# Altium schematic sheet P02_POWER_SUPPLIES_1_OF.SchDoc(P02_POWER_SUPPLIES_1_OF)
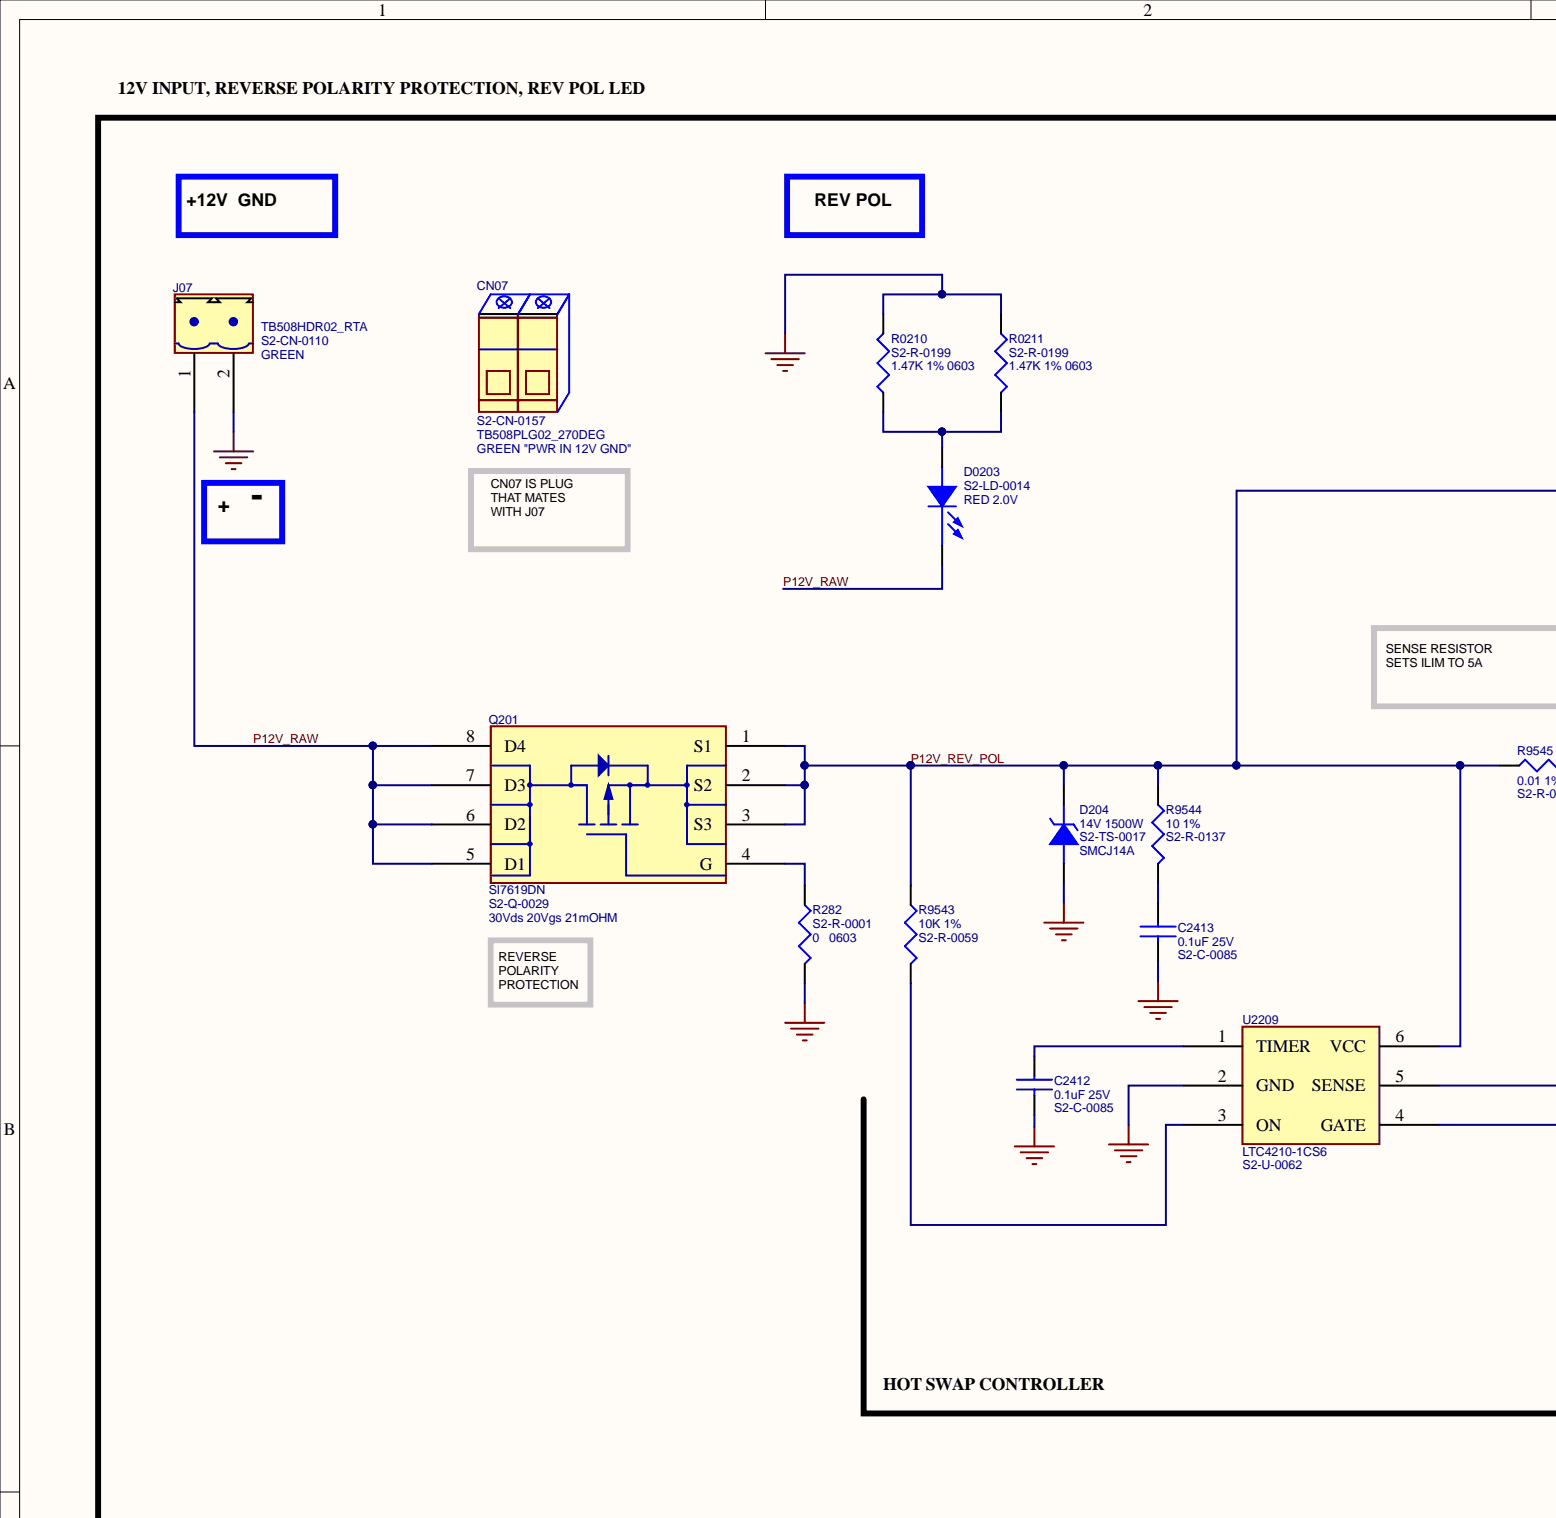
[diagram p0: P02_POWER_SUPPLIES_1_OF.SchDoc, top left]
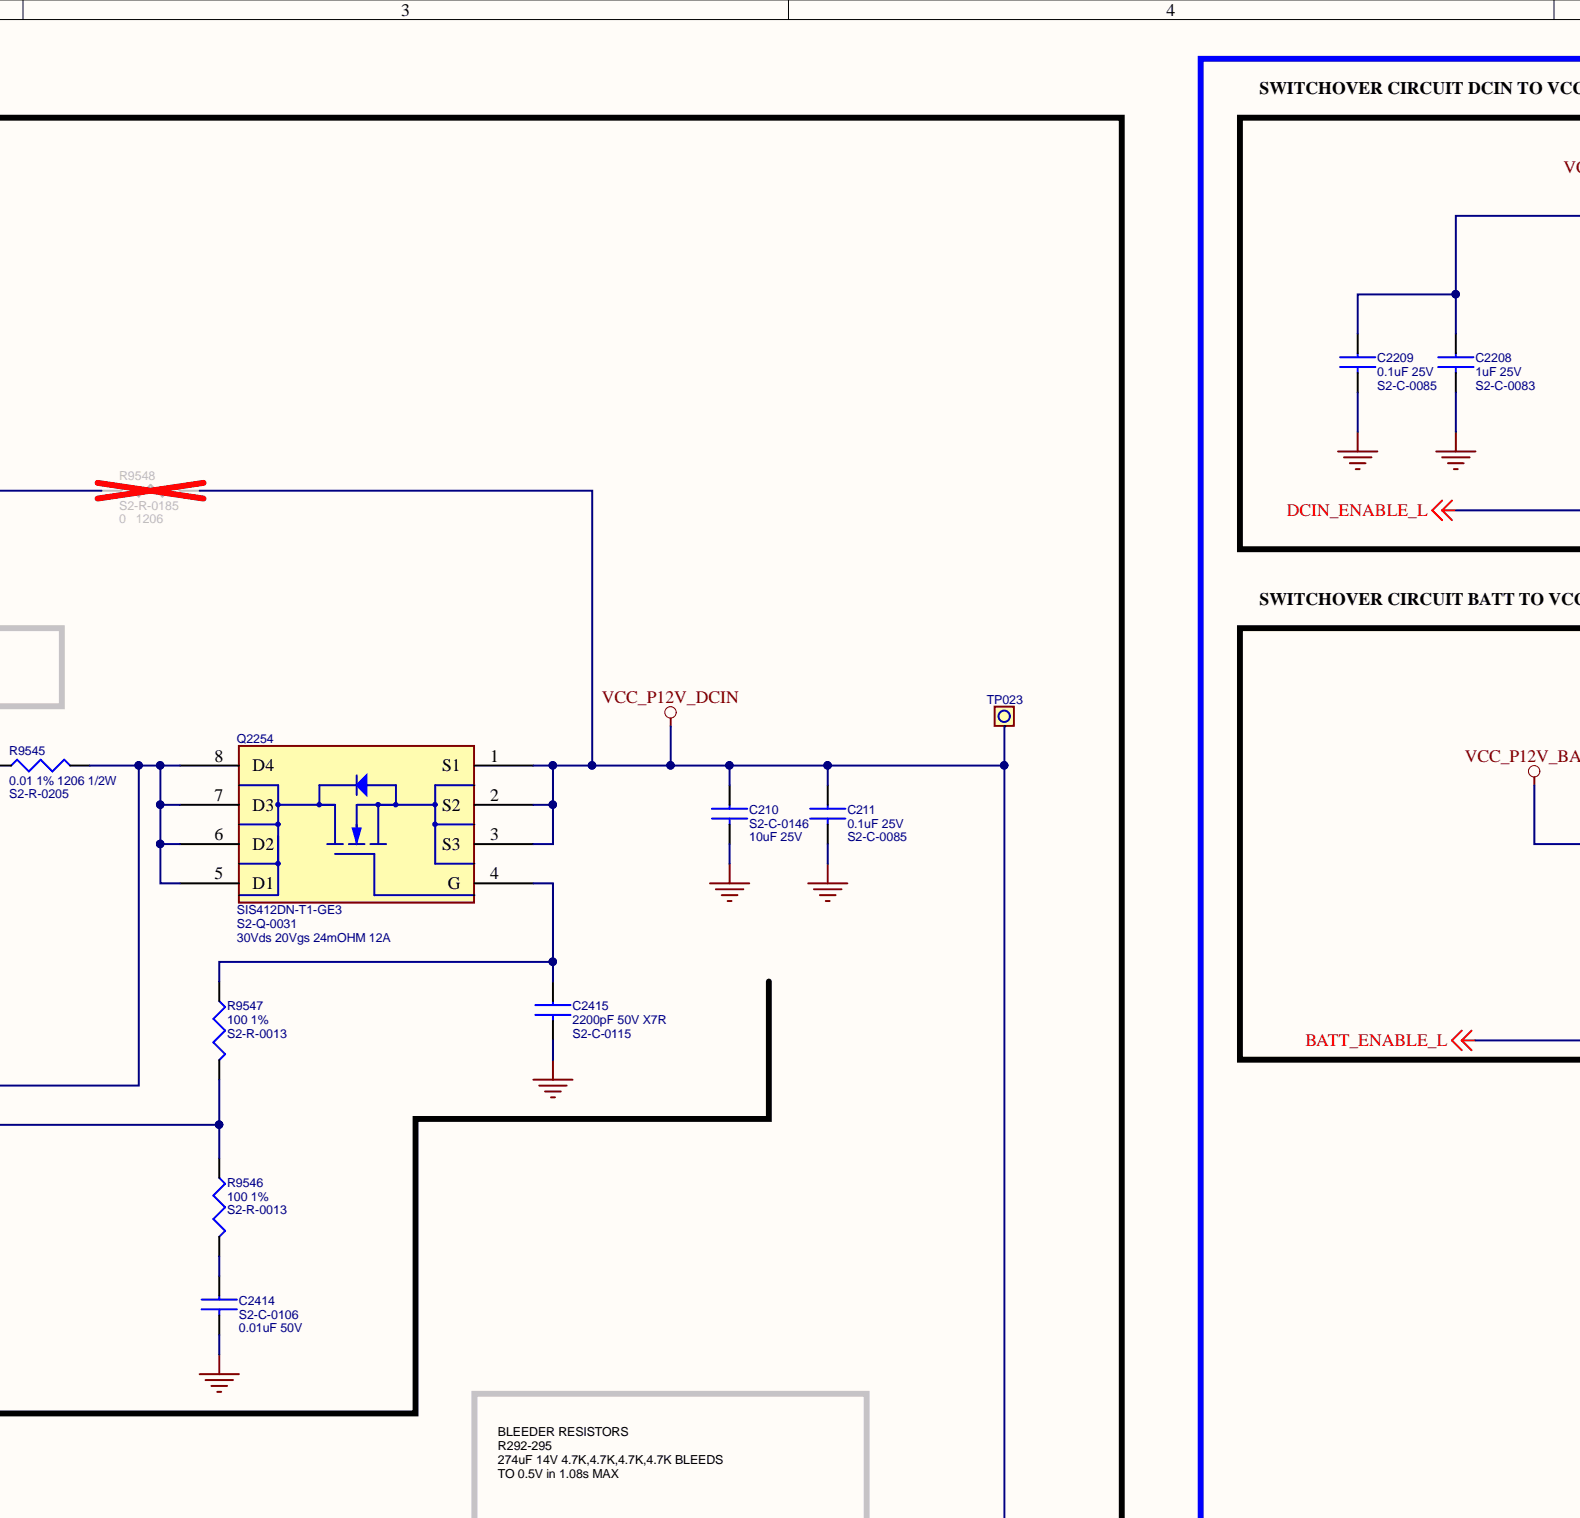
[diagram p1: P02_POWER_SUPPLIES_1_OF.SchDoc, top centre]
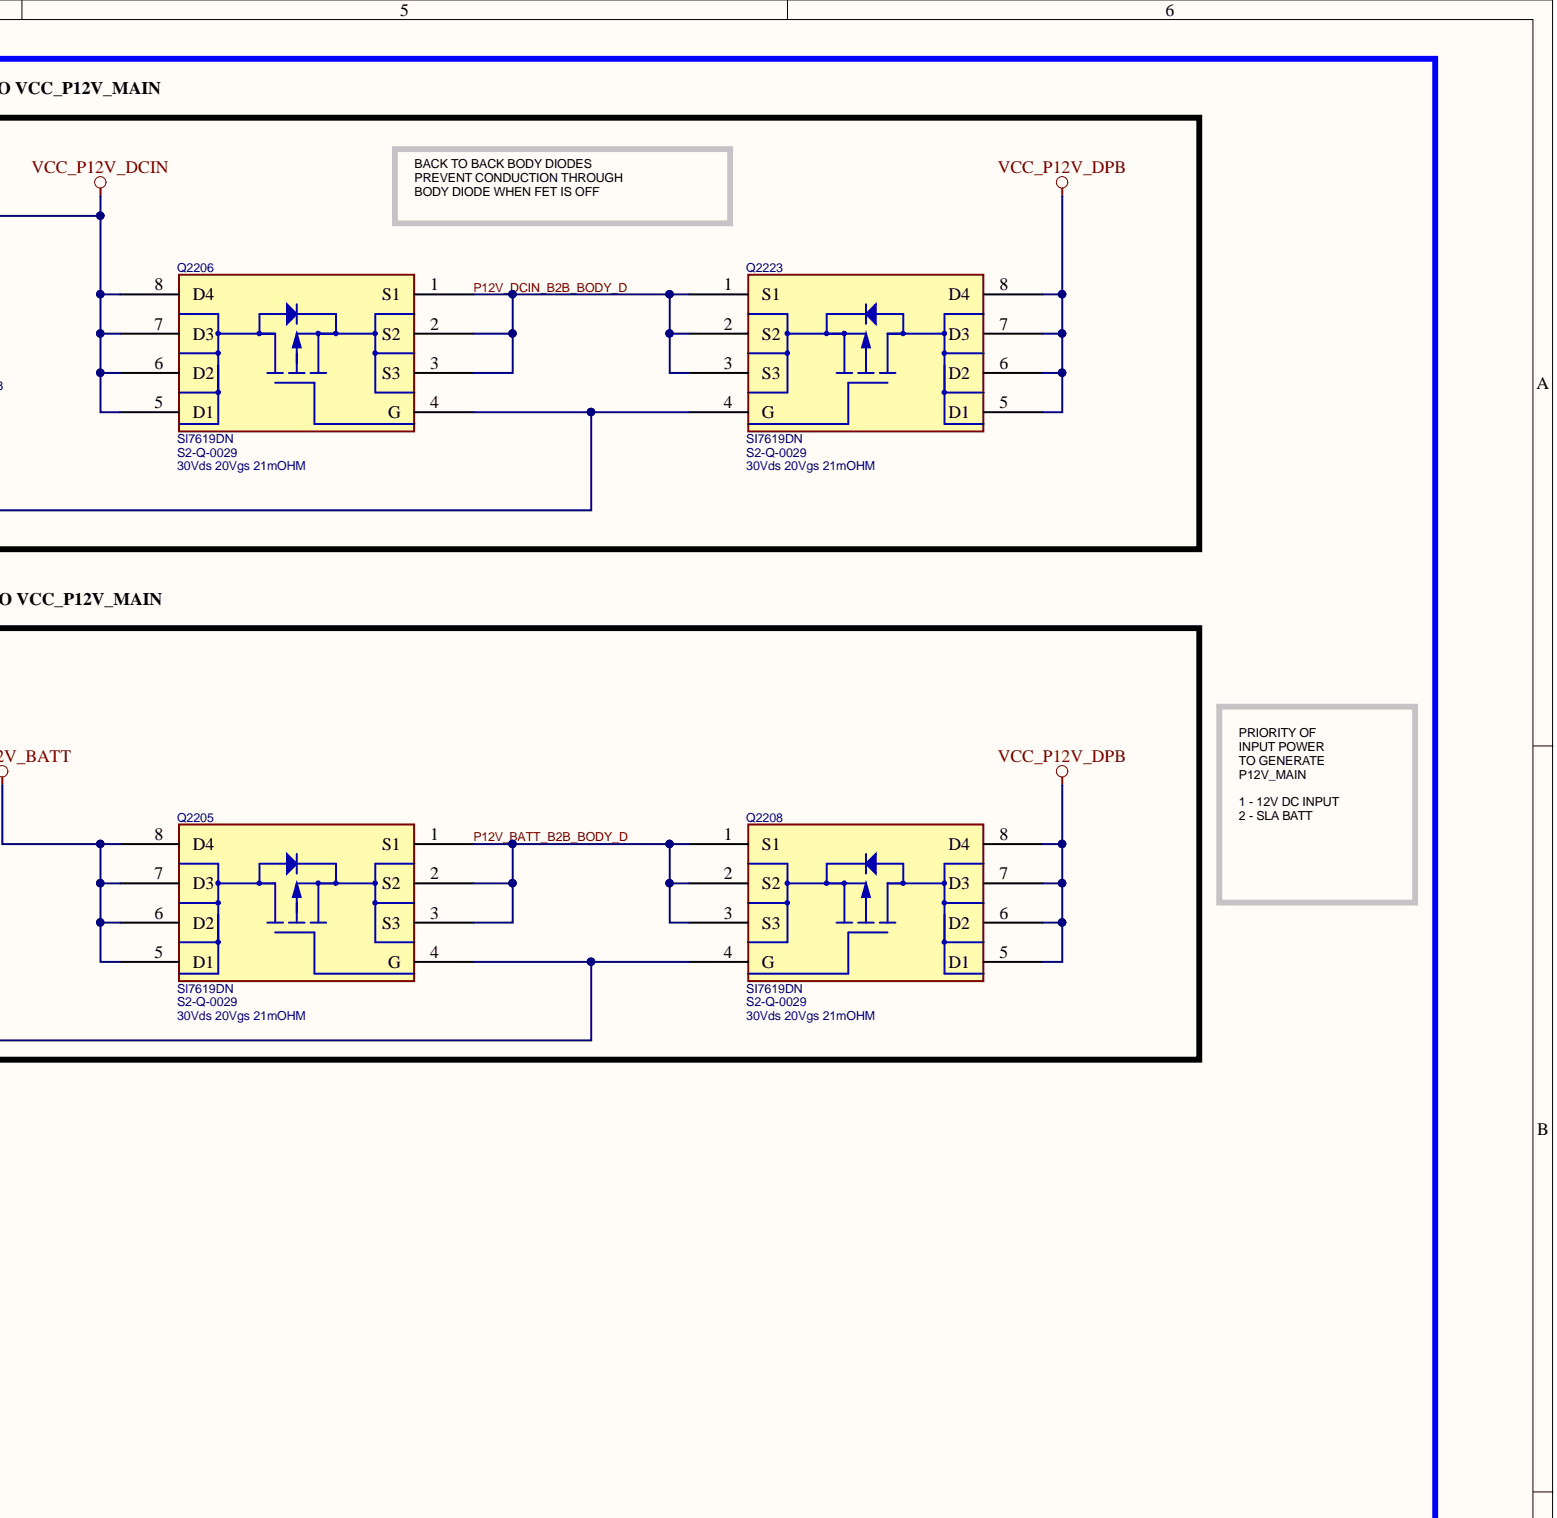
[diagram p2: P02_POWER_SUPPLIES_1_OF.SchDoc, top right]
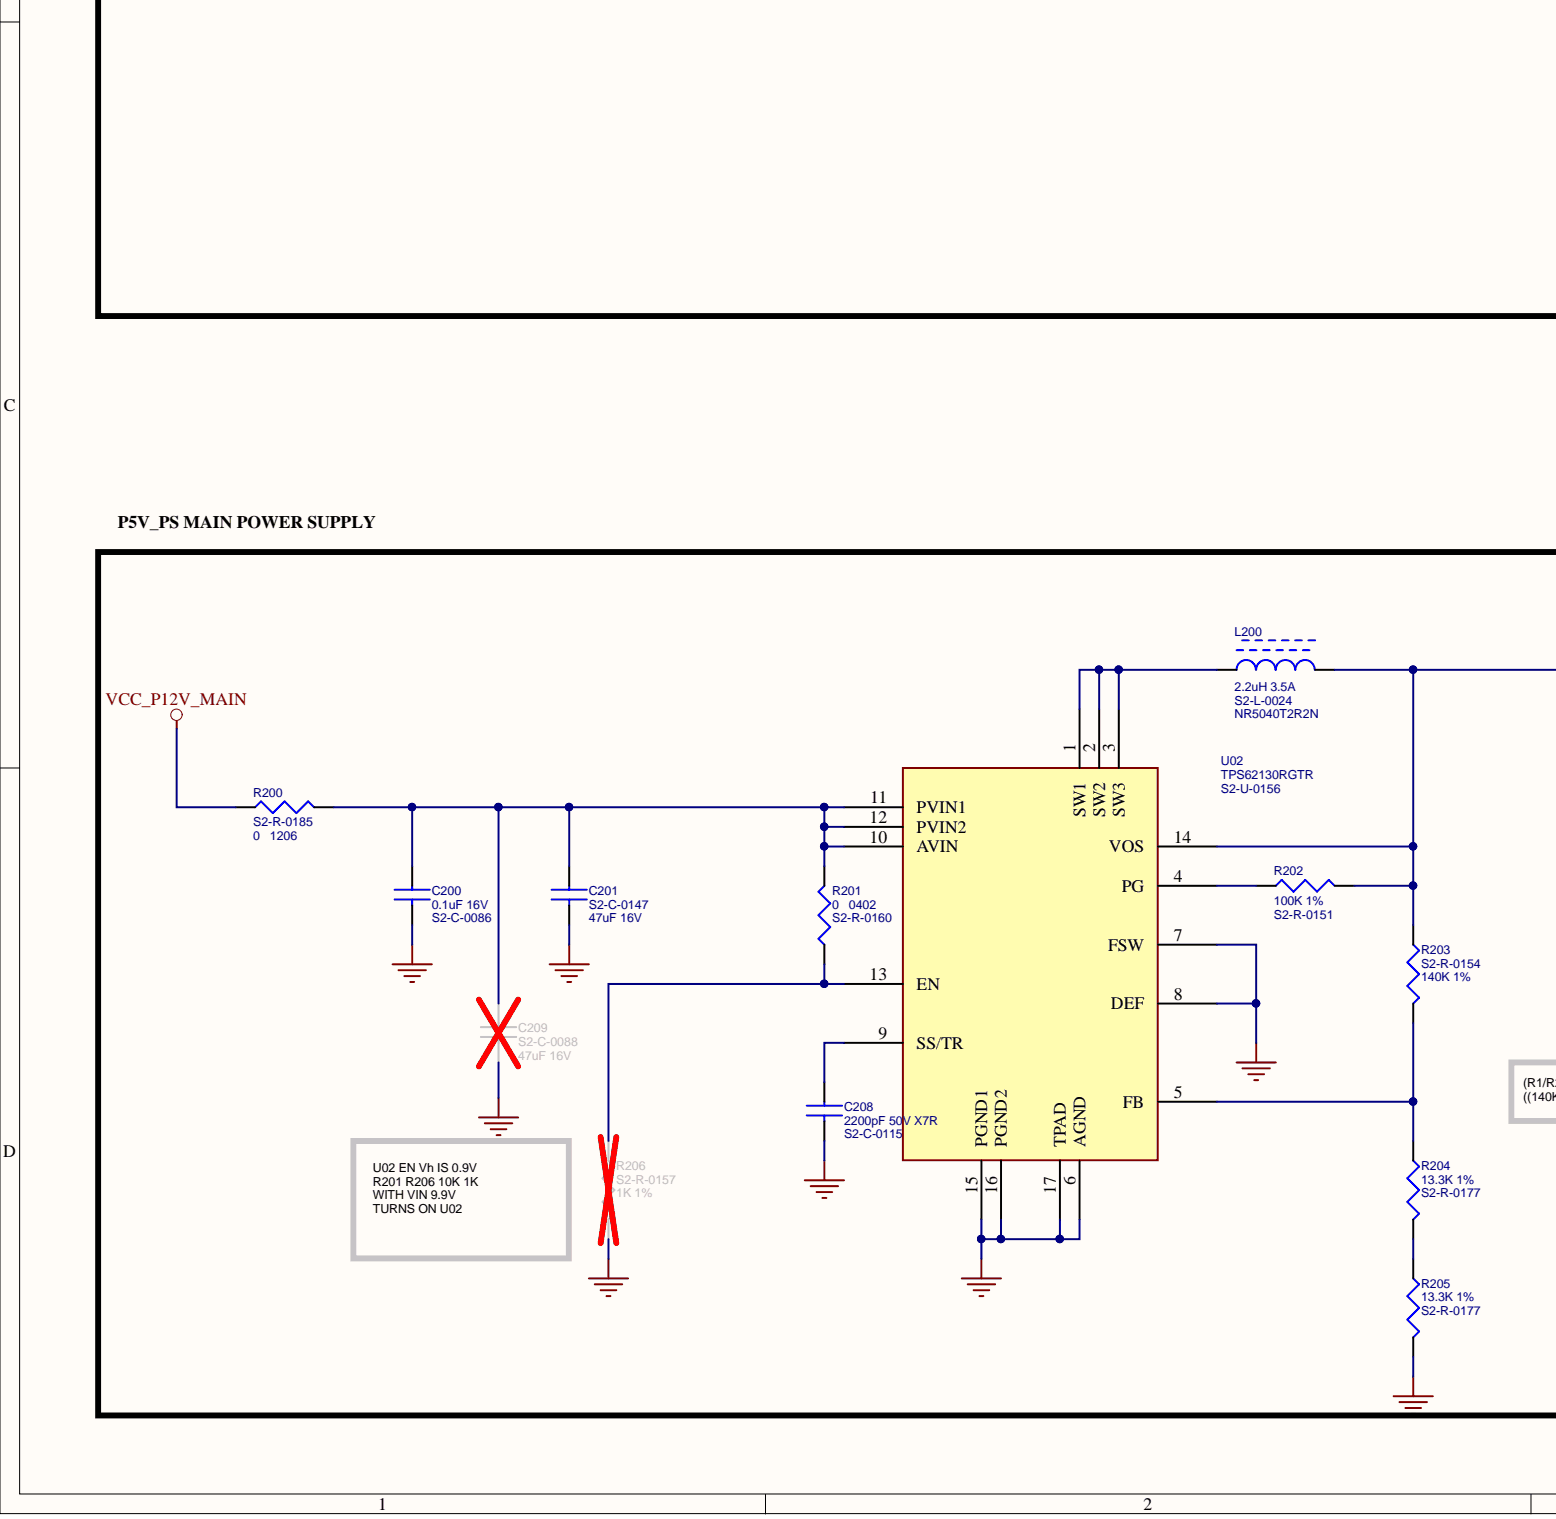
[diagram p3: P02_POWER_SUPPLIES_1_OF.SchDoc, bottom left]
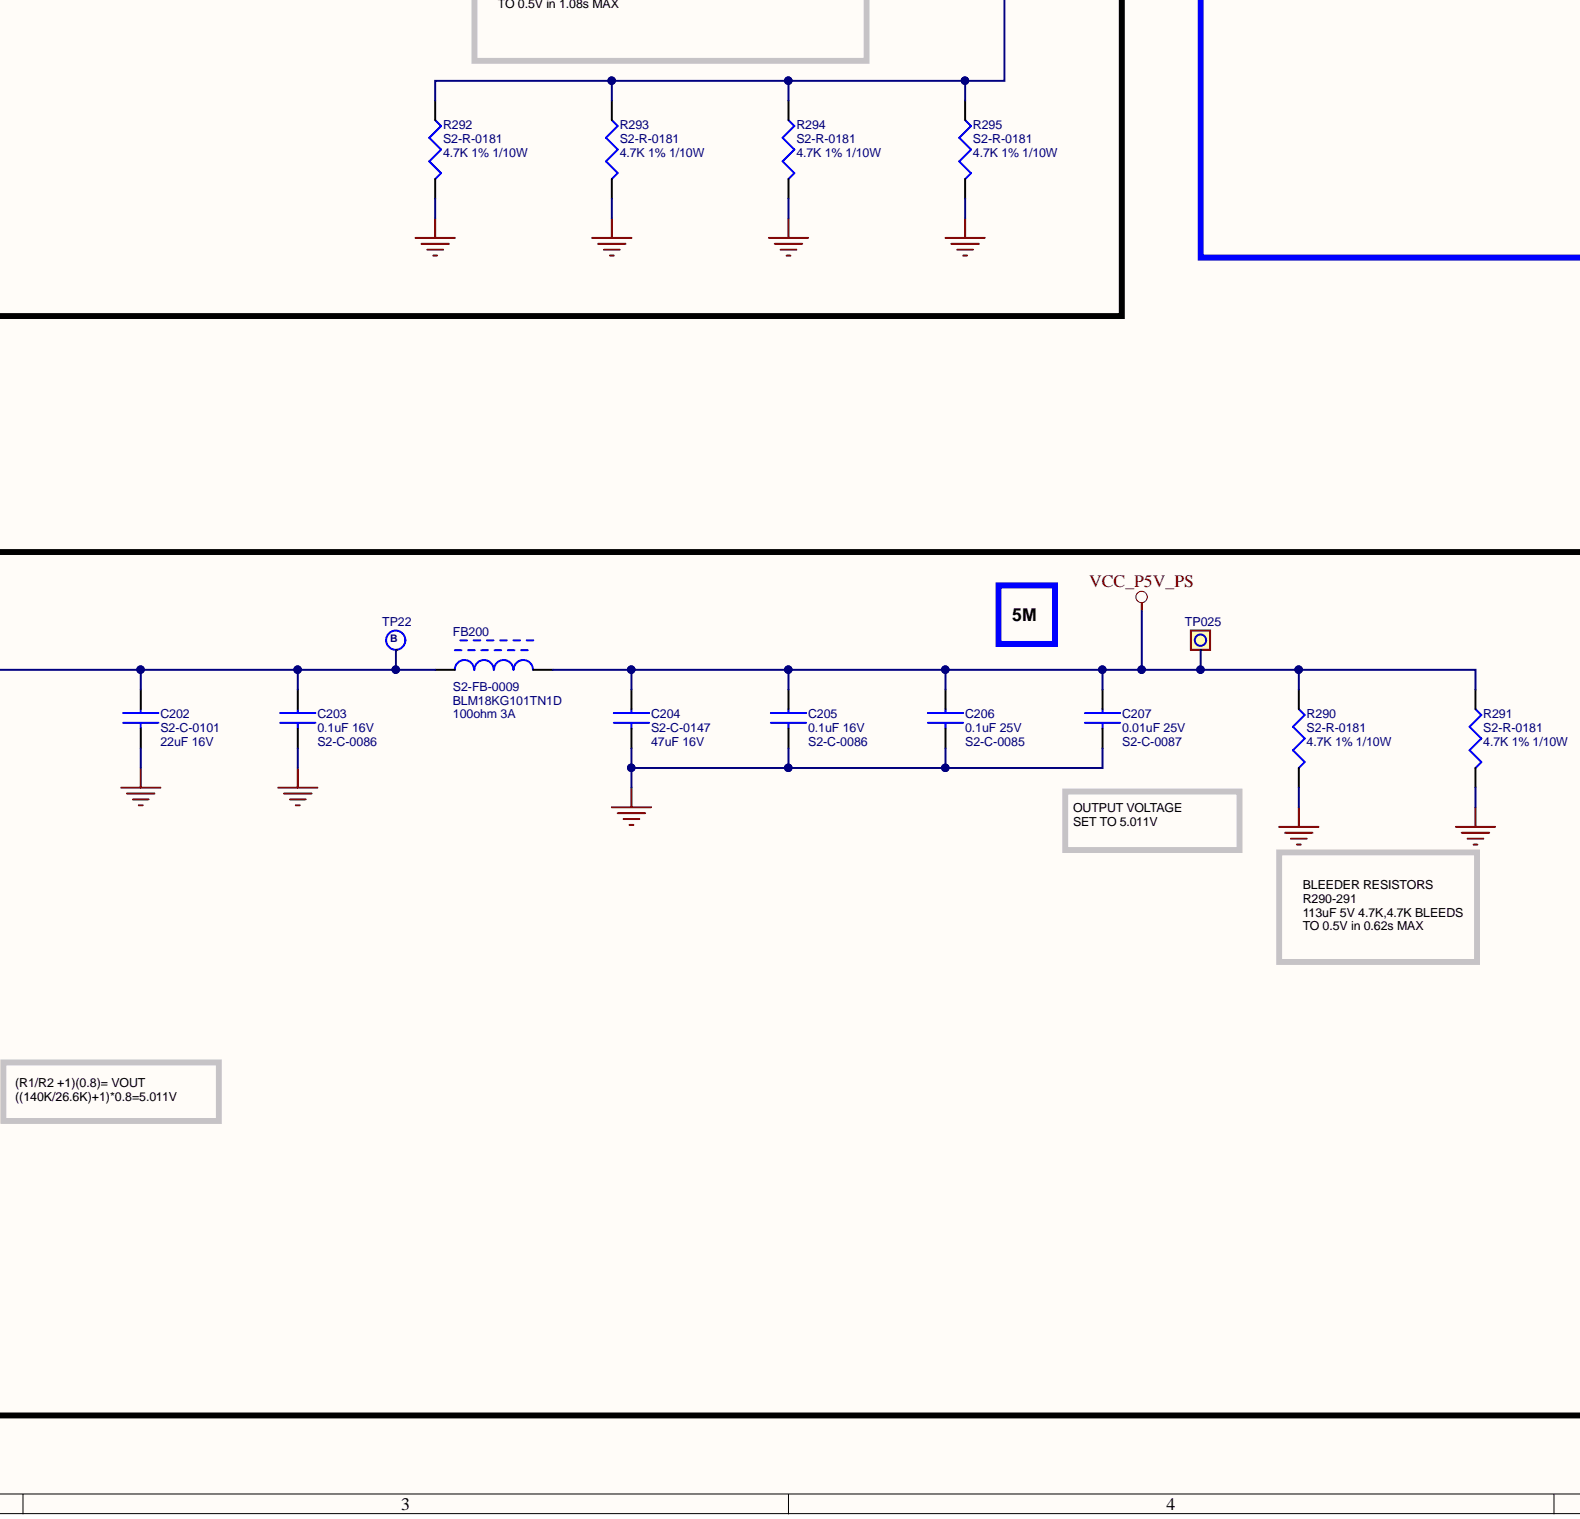
[diagram p4: P02_POWER_SUPPLIES_1_OF.SchDoc, bottom centre]
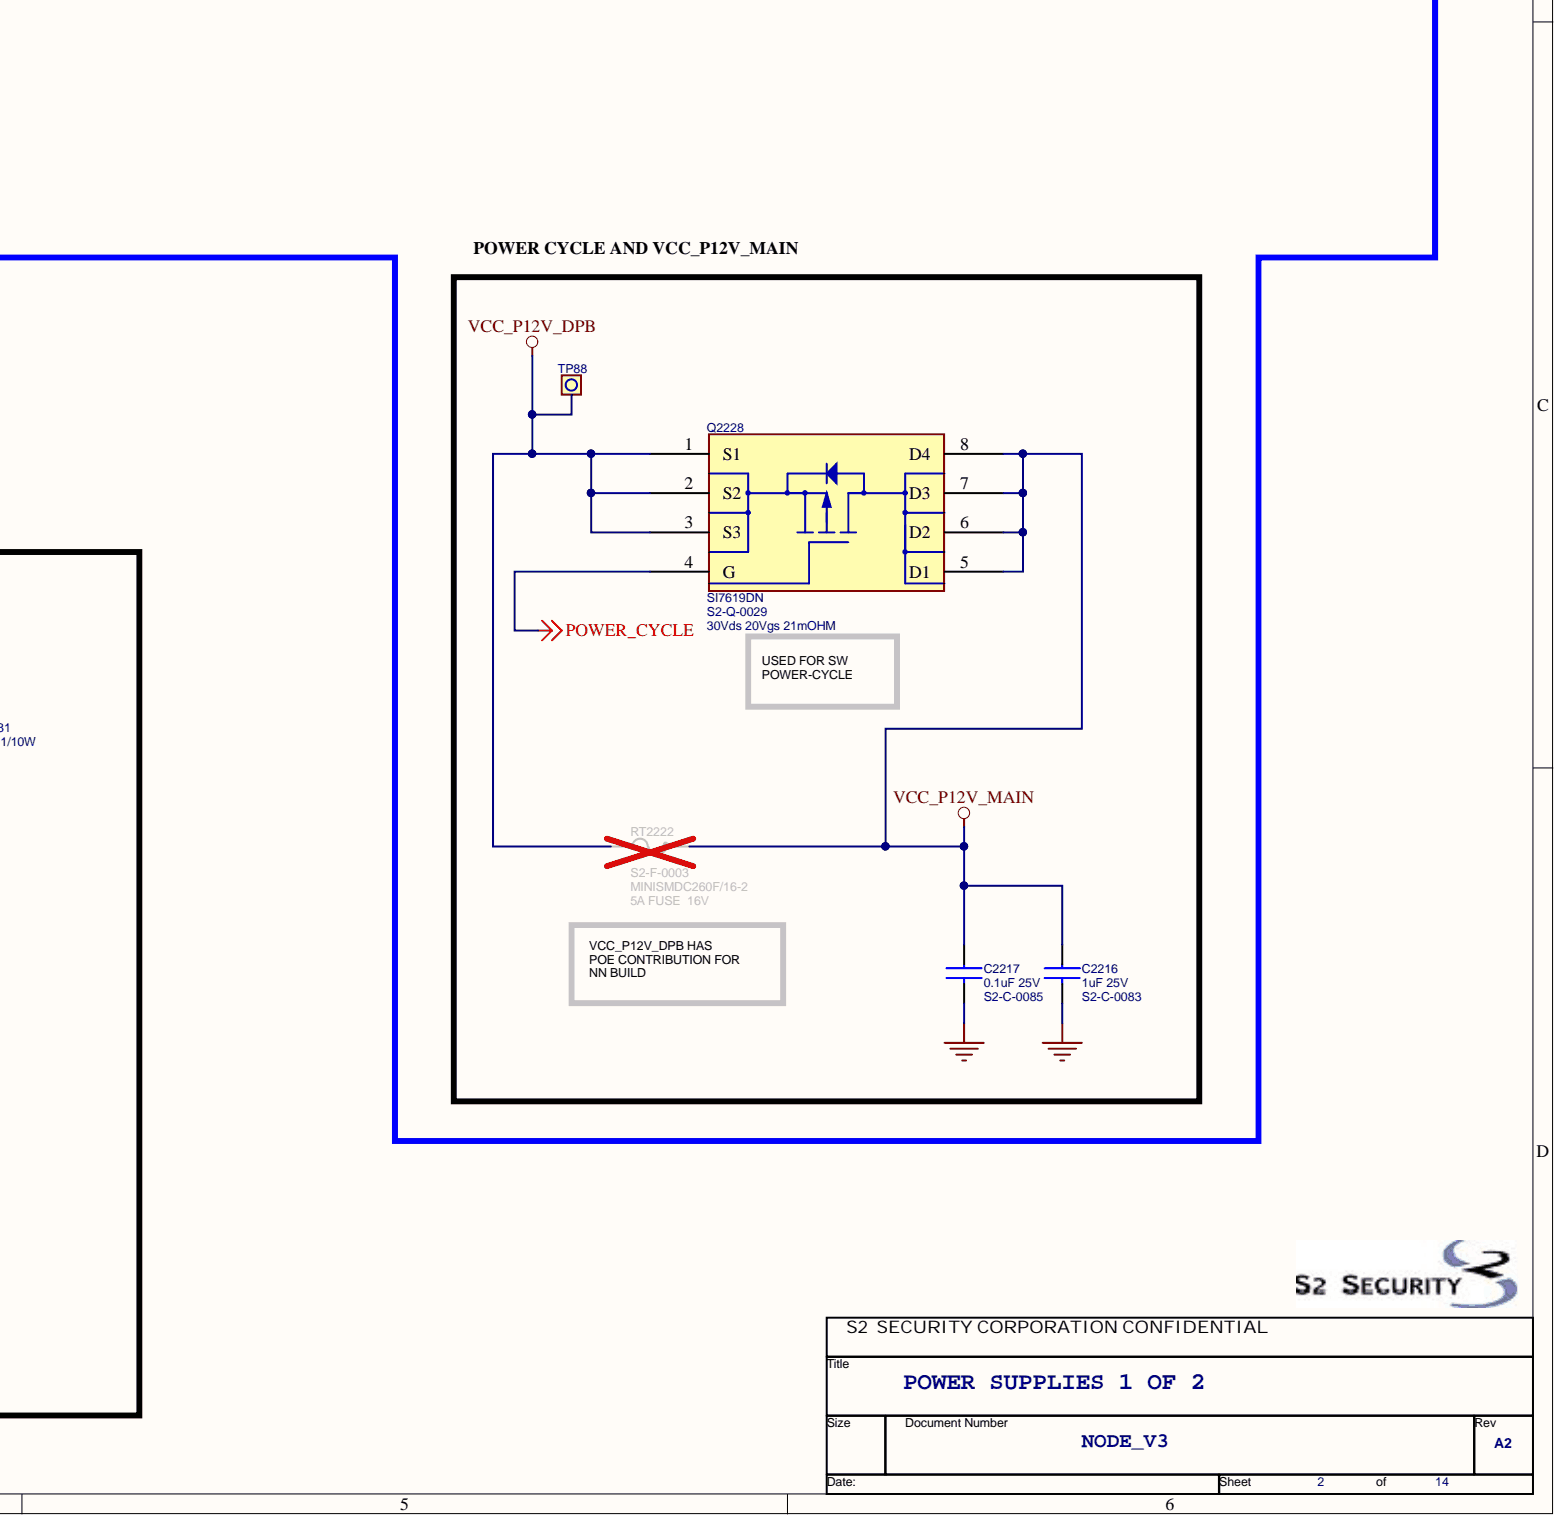
[diagram p5: P02_POWER_SUPPLIES_1_OF.SchDoc, bottom right]

## Parts
C200  S2_PN: S2-C-0086  DESC: 0.1uF 16V  [CAPC1005X55N]  (hidden comment: C_0U1_16V_0402)
C201  S2_PN: S2-C-0147  DESC: 47uF 16V  [CAPC3216X180N]  (hidden comment: C_47U_16V_1206)
C202  S2_PN: S2-C-0101  DESC: 22uF 16V  [CAPC2012X145N]  (hidden comment: C_22U_16V_0805)
C203  S2_PN: S2-C-0086  DESC: 0.1uF 16V  [CAPC1005X55N]  (hidden comment: C_0U1_16V_0402)
C204  S2_PN: S2-C-0147  DESC: 47uF 16V  [CAPC3216X180N]  (hidden comment: C_47U_16V_1206)
C205  S2_PN: S2-C-0086  DESC: 0.1uF 16V  [CAPC1005X55N]  (hidden comment: C_0U1_16V_0402)
C206  S2_PN: S2-C-0085  DESC: 0.1uF 25V  [CAPC1608X90N]  (hidden comment: C_0U1_25V_0603)
C207  S2_PN: S2-C-0087  DESC: 0.01uF 25V  [CAPC1005X55N]  (hidden comment: C_0U01_25V_0402)
C208  S2_PN: S2-C-0115  [CAPC1608X90N]  (hidden comment: C_2200P_50V_0603_X7R)
C209  S2_PN: S2-C-0088  DESC: 47uF 16V  [CAPC3225X270N]  (hidden comment: C_47U_16V_1210)
C210  S2_PN: S2-C-0146  [CAPC2012X145N]  (hidden comment: C_10U_25V_0805)
C211  S2_PN: S2-C-0085  DESC: 0.1uF 25V  [CAPC1608X90N]  (hidden comment: C_0U1_25V_0603)
C2208  S2_PN: S2-C-0083  DESC: 1uF 25V  [CAPC1608X90N]  (hidden comment: C_1U_25V_0603)
C2209  S2_PN: S2-C-0085  DESC: 0.1uF 25V  [CAPC1608X90N]  (hidden comment: C_0U1_25V_0603)
C2216  S2_PN: S2-C-0083  DESC: 1uF 25V  [CAPC1608X90N]  (hidden comment: C_1U_25V_0603)
C2217  S2_PN: S2-C-0085  DESC: 0.1uF 25V  [CAPC1608X90N]  (hidden comment: C_0U1_25V_0603)
C2412  S2_PN: S2-C-0085  DESC: 0.1uF 25V  [CAPC1608X90N]  (hidden comment: C_0U1_25V_0603)
C2413  S2_PN: S2-C-0085  DESC: 0.1uF 25V  [CAPC1608X90N]  (hidden comment: C_0U1_25V_0603)
C2414  S2_PN: S2-C-0106  DESC: 0.01uF 50V  [CAPC1608X90N]  (hidden comment: C_0U01_50V_0603)
C2415  S2_PN: S2-C-0115  [CAPC1608X90N]  (hidden comment: C_2200P_50V_0603_X7R)
CN07  S2_PN: S2-CN-0157  DESC: TB508PLG02_270DEG  ATTR2: GREEN "PWR IN 12V GND"  [N/A]  (hidden comment: CN_5MM08_02PLUG_270DEG_GRN_PWR)
D0203  S2_PN: S2-LD-0014  ATTR: RED 2.0V  [LED_0805]  (hidden comment: LED_0805_RED)
D204  S2_PN: S2-TS-0017  DESC: SMCJ14A  ATTR2: 14V 1500W  [Diode_SMCJ14A]  (hidden comment: D_SMCJ14A_TVS_14V_1500W)
FB200  S2_PN: S2-FB-0009  DESC: BLM18KG101TN1D  ATTR: 100ohm 3A  [FB0603]  (hidden comment: FB_3A_100OHM_0603_DC_30mOHM)
J07  S2_PN: S2-CN-0110  PKG: TB508HDR02_RTA  ATTR2: GREEN  [Conn_5442002]  (hidden comment: CN_5MM08_02POS_HEADER_RTA_GRN)
L200  S2_PN: S2-L-0024  ATTR2: 2.2uH 3.5A  [IND_NR5040T2R2N]  (hidden comment: L_2U2_3A5_NR5040T2R2N)
Q201  S2_PN: S2-Q-0029  DESC: SI7619DN  ATTR2: 30Vds 20Vgs 21mOHM  [POWERPAK_1212X66X340X112X8N]  (hidden comment: Q_POWER_PFET_SI7619DN)
Q2205  S2_PN: S2-Q-0029  DESC: SI7619DN  ATTR2: 30Vds 20Vgs 21mOHM  [POWERPAK_1212X66X340X112X8N]  (hidden comment: Q_POWER_PFET_SI7619DN)
Q2206  S2_PN: S2-Q-0029  DESC: SI7619DN  ATTR2: 30Vds 20Vgs 21mOHM  [POWERPAK_1212X66X340X112X8N]  (hidden comment: Q_POWER_PFET_SI7619DN)
Q2208  S2_PN: S2-Q-0029  DESC: SI7619DN  ATTR2: 30Vds 20Vgs 21mOHM  [POWERPAK_1212X66X340X112X8N]  (hidden comment: Q_POWER_PFET_SI7619DN)
Q2223  S2_PN: S2-Q-0029  DESC: SI7619DN  ATTR2: 30Vds 20Vgs 21mOHM  [POWERPAK_1212X66X340X112X8N]  (hidden comment: Q_POWER_PFET_SI7619DN)
Q2228  S2_PN: S2-Q-0029  DESC: SI7619DN  ATTR2: 30Vds 20Vgs 21mOHM  [POWERPAK_1212X66X340X112X8N]  (hidden comment: Q_POWER_PFET_SI7619DN)
Q2254  S2_PN: S2-Q-0031  DESC: SIS412DN-T1-GE3  ATTR2: 30Vds 20Vgs 24mOHM 12A  [PowerPAK_330X330X120_COMMON]  (hidden comment: Q_POWER_NFET_SIS412DN)
R200  S2_PN: S2-R-0185  DESC: 0   1206  [RESC3216X60N]  (hidden comment: R_0_1206)
R201  S2_PN: S2-R-0160  DESC: 0   0402  [RESC1005X40N]  (hidden comment: R_0_0402)
R202  S2_PN: S2-R-0151  DESC: 100K 1%  [RESC1005X40N]  (hidden comment: R_100K_0402)
R203  S2_PN: S2-R-0154  DESC: 140K 1%  [RESC1005X40N]  (hidden comment: R_140K_0402)
R204  S2_PN: S2-R-0177  DESC: 13.3K 1%  [RESC1005X40N]  (hidden comment: R_13K3_0402)
R205  S2_PN: S2-R-0177  DESC: 13.3K 1%  [RESC1005X40N]  (hidden comment: R_13K3_0402)
R206  S2_PN: S2-R-0157  DESC: 1K 1%  [RESC1005X40N]  (hidden comment: R_1K_0402)
R0210  S2_PN: S2-R-0199  [RESC1608X55N]  (hidden comment: R_1K47_0603)
R0211  S2_PN: S2-R-0199  DESC: 1.47K 1% 0603  [RESC1608X55N]  (hidden comment: R_1K47_0603)
R282  S2_PN: S2-R-0001  DESC: 0   0603  [RESC1608X55N]  (hidden comment: R_0_0603)
R290  S2_PN: S2-R-0181  DESC: 4.7K 1% 1/10W  [RESC1608X55N]  (hidden comment: R_4K7_0603)
R291  S2_PN: S2-R-0181  DESC: 4.7K 1% 1/10W  [RESC1608X55N]  (hidden comment: R_4K7_0603)
R292  S2_PN: S2-R-0181  DESC: 4.7K 1% 1/10W  [RESC1608X55N]  (hidden comment: R_4K7_0603)
R293  S2_PN: S2-R-0181  DESC: 4.7K 1% 1/10W  [RESC1608X55N]  (hidden comment: R_4K7_0603)
R294  S2_PN: S2-R-0181  DESC: 4.7K 1% 1/10W  [RESC1608X55N]  (hidden comment: R_4K7_0603)
R295  S2_PN: S2-R-0181  DESC: 4.7K 1% 1/10W  [RESC1608X55N]  (hidden comment: R_4K7_0603)
R9543  S2_PN: S2-R-0059  DESC: 10K 1%  [RESC1608X55N]  (hidden comment: R_10K_0603)
R9544  S2_PN: S2-R-0137  DESC: 10 1%  [RESC1608X55N]  (hidden comment: R_10_0603)
R9545  S2_PN: S2-R-0205  DESC: 0.01 1% 1206 1/2W  [RESC3216X60N]  (hidden comment: R_0R01_1206)
R9546  S2_PN: S2-R-0013  DESC: 100 1%  [RESC1608X55N]  (hidden comment: R_100_0603)
R9547  S2_PN: S2-R-0013  DESC: 100 1%  [RESC1608X55N]  (hidden comment: R_100_0603)
R9548  S2_PN: S2-R-0185  DESC: 0   1206  [RESC3216X60N]  (hidden comment: R_0_1206)
RT2222  S2_PN: S2-F-0003  DESC: MINISMDC260F/16-2  ATTR: 5A FUSE  16V  [RESC4532X70N]  (hidden comment: FUSE_PTC_5A_16V_1812)
TP22  TP_SMT_TEST_POINT_BOTTOM  [TP35_SMT]  (value not drawn)
TP023  TP_TH_TEST_POINT_SQUARE  [TEST-PT-TH-SQ]  (value not drawn)
TP025  TP_TH_TEST_POINT_SQUARE  [TEST-PT-TH-SQ]  (value not drawn)
TP88  TP_TH_TEST_POINT_SQUARE  [TEST-PT-TH-SQ]  (value not drawn)
U02  S2_PN: S2-U-0156  DESC: TPS62130RGTR  [QFN050PX310x100-16N]  (hidden comment: U_TPS62130RGTR_BUCK_17V_3A)
U2209  S2_PN: S2-U-0062  DESC: LTC4210-1CS6  [SOT95P280X145-6N]  (hidden comment: U_LTC4210-1CS6)

## Nets
BATT_ENABLE_L: Q2205.4(G) Q2208.4(G)
DCIN_ENABLE_L: Q2206.4(G) Q2223.4(G)
GND: C200.2 C201.2 C202.2 C203.2 C204.2 C205.2 C206.2 C207.2 C208.2 C209.2 C210.2 C211.2 C2208.2 C2209.2 C2216.2 C2217.2 C2412.2 C2413.2 C2414.2 C2415.2 D204.1 J07.2 R205.2 R206.2 R0210.1 R0211.1 R282.2 R290.2 R291.2 R292.2 R293.2 R294.2 R295.2 U02.6(AGND) U02.7(FSW) U02.8(DEF) U02.15(PGND1) U02.16(PGND2) U02.17(TPAD) U2209.2(GND)
POWER_CYCLE: Q2228.4(G)
VCC_P5V_PS: C204.1 C205.1 C206.1 C207.1 FB200.2 R290.1 R291.1 TP025.1
VCC_P12V_BATT: Q2205.5(D1) Q2205.6(D2) Q2205.7(D3) Q2205.8(D4)
VCC_P12V_DCIN: C210.1 C211.1 C2208.1 C2209.1 Q2206.5(D1) Q2206.6(D2) Q2206.7(D3) Q2206.8(D4) Q2254.1(S1) Q2254.2(S2) Q2254.3(S3) R292.1 R293.1 R294.1 R295.1 R9548.2 TP023.1
VCC_P12V_DPB: Q2208.5(D1) Q2208.6(D2) Q2208.7(D3) Q2208.8(D4) Q2223.5(D1) Q2223.6(D2) Q2223.7(D3) Q2223.8(D4) Q2228.1(S1) Q2228.2(S2) Q2228.3(S3) RT2222.1 TP88.1
VCC_P12V_MAIN: C2216.1 C2217.1 Q2228.5(D1) Q2228.6(D2) Q2228.7(D3) Q2228.8(D4) R200.1 RT2222.2
P12V_BATT_B2B_BODY_D: Q2205.1(S1) Q2205.2(S2) Q2205.3(S3) Q2208.1(S1) Q2208.2(S2) Q2208.3(S3)
P12V_DCIN_B2B_BODY_D: Q2206.1(S1) Q2206.2(S2) Q2206.3(S3) Q2223.1(S1) Q2223.2(S2) Q2223.3(S3)
P12V_RAW: D0203.2 J07.1 Q201.5(D1) Q201.6(D2) Q201.7(D3) Q201.8(D4)
P12V_REV_POL: D204.2 Q201.1(S1) Q201.2(S2) Q201.3(S3) R9543.1 R9544.1 R9545.1 R9548.1 U2209.6(VCC)
NetC200_1: C200.1 C201.1 C209.1 R200.2 R201.1 U02.10(AVIN) U02.11(PVIN1) U02.12(PVIN2)
NetC202_1: C202.1 C203.1 FB200.1 L200.2 R202.2 R203.1 TP22.1 U02.14(VOS)
NetC208_1: C208.1 U02.9(SS/TR)
NetC2412_1: C2412.1 U2209.1(TIMER)
NetC2413_1: C2413.1 R9544.2
NetC2414_1: C2414.1 R9546.2
NetC2415_1: C2415.1 Q2254.4(G) R9547.1
NetD0203_1: D0203.1 R0210.2 R0211.2
NetL200_1: L200.1 U02.1(SW1) U02.2(SW2) U02.3(SW3)
NetQ201_4: Q201.4(G) R282.1
NetQ2254_5: Q2254.5(D1) Q2254.6(D2) Q2254.7(D3) Q2254.8(D4) R9545.2 U2209.5(SENSE)
NetR201_2: R201.2 R206.1 U02.13(EN)
NetR202_1: R202.1 U02.4(PG)
NetR203_2: R203.2 R204.1 U02.5(FB)
NetR204_2: R204.2 R205.1
NetR9543_2: R9543.2 U2209.3(ON)
NetR9546_1: R9546.1 R9547.2 U2209.4(GATE)

## Library data of the parts
C200 C203 C205 = C_0U1_16V_0402: PKG: CC0402IPC; ATTR: X7R 10%; Library Name: NODE_V2_M1.SCHLIB; Component Kind: Standard
C201 C204 = C_47U_16V_1206: PKG: CC1206IPC; ATTR: X5R 20%; Library Name: NODE_V2_M1.SCHLIB; Component Kind: Standard
C202 = C_22U_16V_0805: PKG: CC0805IPC; ATTR: X5R 20%; Library Name: NODE_V2_M1.SCHLIB; Component Kind: Standard
C206 C211 C2209 C2217 C2412 C2413 = C_0U1_25V_0603: PKG: CC0603IPC; ATTR: X7R 10%; Library Name: NODE_V2_M1.SCHLIB; Component Kind: Standard
C207 = C_0U01_25V_0402: PKG: CC0402IPC; ATTR: X7R 10%; Library Name: NODE_V2_M1.SCHLIB; Component Kind: Standard
C208 C2415 = C_2200P_50V_0603_X7R: PKG: CC0603IPC; DESC: 2200pF 50V X7R; ATTR: X7R 10%; Library Name: NODE_V2_M1.SCHLIB; Component Kind: Standard
C209 = C_47U_16V_1210: PKG: CC1210IPC; ATTR: X5R 20%; Library Name: NODE_V2_M1.SCHLIB; Component Kind: Standard
C210 = C_10U_25V_0805: PKG: CC0805IPC; DESC: 10uF 25V; ATTR: X7R 10%; Library Name: NODE_V2_M1.SCHLIB; Component Kind: Standard
C2208 C2216 = C_1U_25V_0603: PKG: CC0603IPC; ATTR: X7R 10%; Library Name: NODE_V2_M1.SCHLIB; Component Kind: Standard
C2414 = C_0U01_50V_0603: PKG: CC0603IPC; ATTR: X7R 10%; Library Name: NODE_V2_M1.SCHLIB; Component Kind: Standard
CN07 = CN_5MM08_02PLUG_270DEG_GRN_PWR: PKG: TB508PLUG02_180DEG; ATTR: PLUG TERM BLK 5.08MM 2POS 270DEG GREEN; Library Name: NODE_V2_M1.SCHLIB; Component Kind: Standard
D0203 = LED_0805_RED: DESC: LED 0805 SUPER RED 2.0V 54MCD; PKG: LED_0805; Library Name: NODE_V2_M1.SCHLIB; Component Kind: Standard
D204 = D_SMCJ14A_TVS_14V_1500W: PKG: SMC; ATTR: TVS UNI-DIR 14V 1500W; Library Name: NODE_V2_M1.SCHLIB; Component Kind: Standard
FB200 = FB_3A_100OHM_0603_DC_30mOHM: PKG: CC0603IPC; Library Name: NODE_V2_M1.SCHLIB; Component Kind: Standard
J07 = CN_5MM08_02POS_HEADER_RTA_GRN: ATTR: HEADER TERM BLK 5.08MM 2POS RTA GREEN; Library Name: NODE_V2_M1.SCHLIB; DESC: TB508HDR02_RTA; Component Kind: Standard
L200 = L_2U2_3A5_NR5040T2R2N: PKG: NR5040; ATTR: INDUCTOR 2.2uH 30% 0.029ohm 3.5A; Library Name: NODE_V2_M1.SCHLIB; DESC: NR5040T2R2N; Component Kind: Standard
Q201 Q2205 Q2206 Q2208 Q2223 Q2228 = Q_POWER_PFET_SI7619DN: PKG: PowerPAK_1212-8; ATTR: MOSFET P-CH 21mOHM 30V 24A PowerPAK 1212-8; Library Name: NODE_V2_M1.SCHLIB; Component Kind: Standard
Q2254 = Q_POWER_NFET_SIS412DN: PKG: PowerPAK_1212-8; ATTR: MOSFET N-CH 24mOHM 30V 12A PowerPAK 1212-8; Library Name: NODE_V2_M1.SCHLIB; Component Kind: Standard
R200 R9548 = R_0_1206: PKG: CC1206IPC; ATTR: RES 0 OHM 1/4W 0.020MAX 1206 3.5A; Library Name: NODE_V2_M1.SCHLIB; Component Kind: Standard
R201 = R_0_0402: PKG: CC0402IPC; ATTR: 1/16W  50mohm MAX; Library Name: NODE_V2_M1.SCHLIB; Component Kind: Standard
R202 = R_100K_0402: PKG: CC0402IPC; ATTR: 1/16W; Library Name: NODE_V2_M1.SCHLIB; Component Kind: Standard
R203 = R_140K_0402: PKG: CC0402IPC; ATTR: 1/16W; Library Name: NODE_V2_M1.SCHLIB; Component Kind: Standard
R204 R205 = R_13K3_0402: PKG: CC0402IPC; ATTR: 1/16W; Library Name: NODE_V2_M1.SCHLIB; Component Kind: Standard
R206 = R_1K_0402: PKG: CC0402IPC; ATTR: 1/16W; Library Name: NODE_V2_M1.SCHLIB; Component Kind: Standard
R0210 = R_1K47_0603: PKG: CC0603IPC; DESC: 1.47K 1% 0603; ATTR: RES 1.47K OHM 1/10W 1% 0603; Library Name: NODE_V2_M1.SCHLIB; Component Kind: Standard
R0211 = R_1K47_0603: PKG: CC0603IPC; ATTR: RES 1.47K OHM 1/10W 1% 0603; Library Name: NODE_V2_M1.SCHLIB; Component Kind: Standard
R282 = R_0_0603: PKG: CC0603IPC; ATTR: 1/10W 50mohm MAX; Library Name: NODE_V2_M1.SCHLIB; Component Kind: Standard
R290-R295 = R_4K7_0603: PKG: CC0603IPC; ATTR: RES 4.7K OHM 1/10W 1% 0603; Library Name: NODE_V2_M1.SCHLIB; Component Kind: Standard
R9543 = R_10K_0603: PKG: CC0603IPC; ATTR: 1/10W; Library Name: NODE_V2_M1.SCHLIB; Component Kind: Standard
R9544 = R_10_0603: PKG: CC0603IPC; ATTR: 1/10W; Library Name: NODE_V2_M1.SCHLIB; Component Kind: Standard
R9545 = R_0R01_1206: PKG: CC1206IPC; ATTR: 1/4W; Library Name: NODE_V2_M1.SCHLIB; Component Kind: Standard
R9546 R9547 = R_100_0603: PKG: CC0603IPC; ATTR: 1/10W; Library Name: NODE_V2_M1.SCHLIB; Component Kind: Standard
RT2222 = FUSE_PTC_5A_16V_1812: PKG: CC1812IPC; ATTR2: PTC RESETTABLE FUSE 1812 16V W/ 5A TRIP; Library Name: NODE_V2_M1.SCHLIB; Component Kind: Standard
TP22 = TP_SMT_TEST_POINT_BOTTOM: S2_PN: S2-TP-0003; PKG: TEST-PT-TPB; DESC: TEST POINT; ATTR: SMT BOTTOM SIDE TEST POINT; Library Name: NODE_V2_M1.SCHLIB; DNP: DNP; Component Kind: Standard
TP023 TP025 TP88 = TP_TH_TEST_POINT_SQUARE: S2_PN: S2-TP-0004; PKG: TEST-PT-TH; DESC: TEST POINT; ATTR: THRU HOLE TEST POINT; Library Name: NODE_V2_M1.SCHLIB; DNP: DNP; Component Kind: Standard
U02 = U_TPS62130RGTR_BUCK_17V_3A: PKG: QFN-16_3X3_6215X; ATTR: IC REG STEP-DOWN CONV 3A  3V-17V; Library Name: NODE_V2_M1.SCHLIB; Component Kind: Standard
U2209 = U_LTC4210-1CS6: PKG: SOT-23-6; ATTR: IC HOT SWAP CONTROLLER; Library Name: NODE_V2_M1.SCHLIB; Component Kind: Standard
